# Altium schematic sheet ZB2.SchDoc(ZB2)
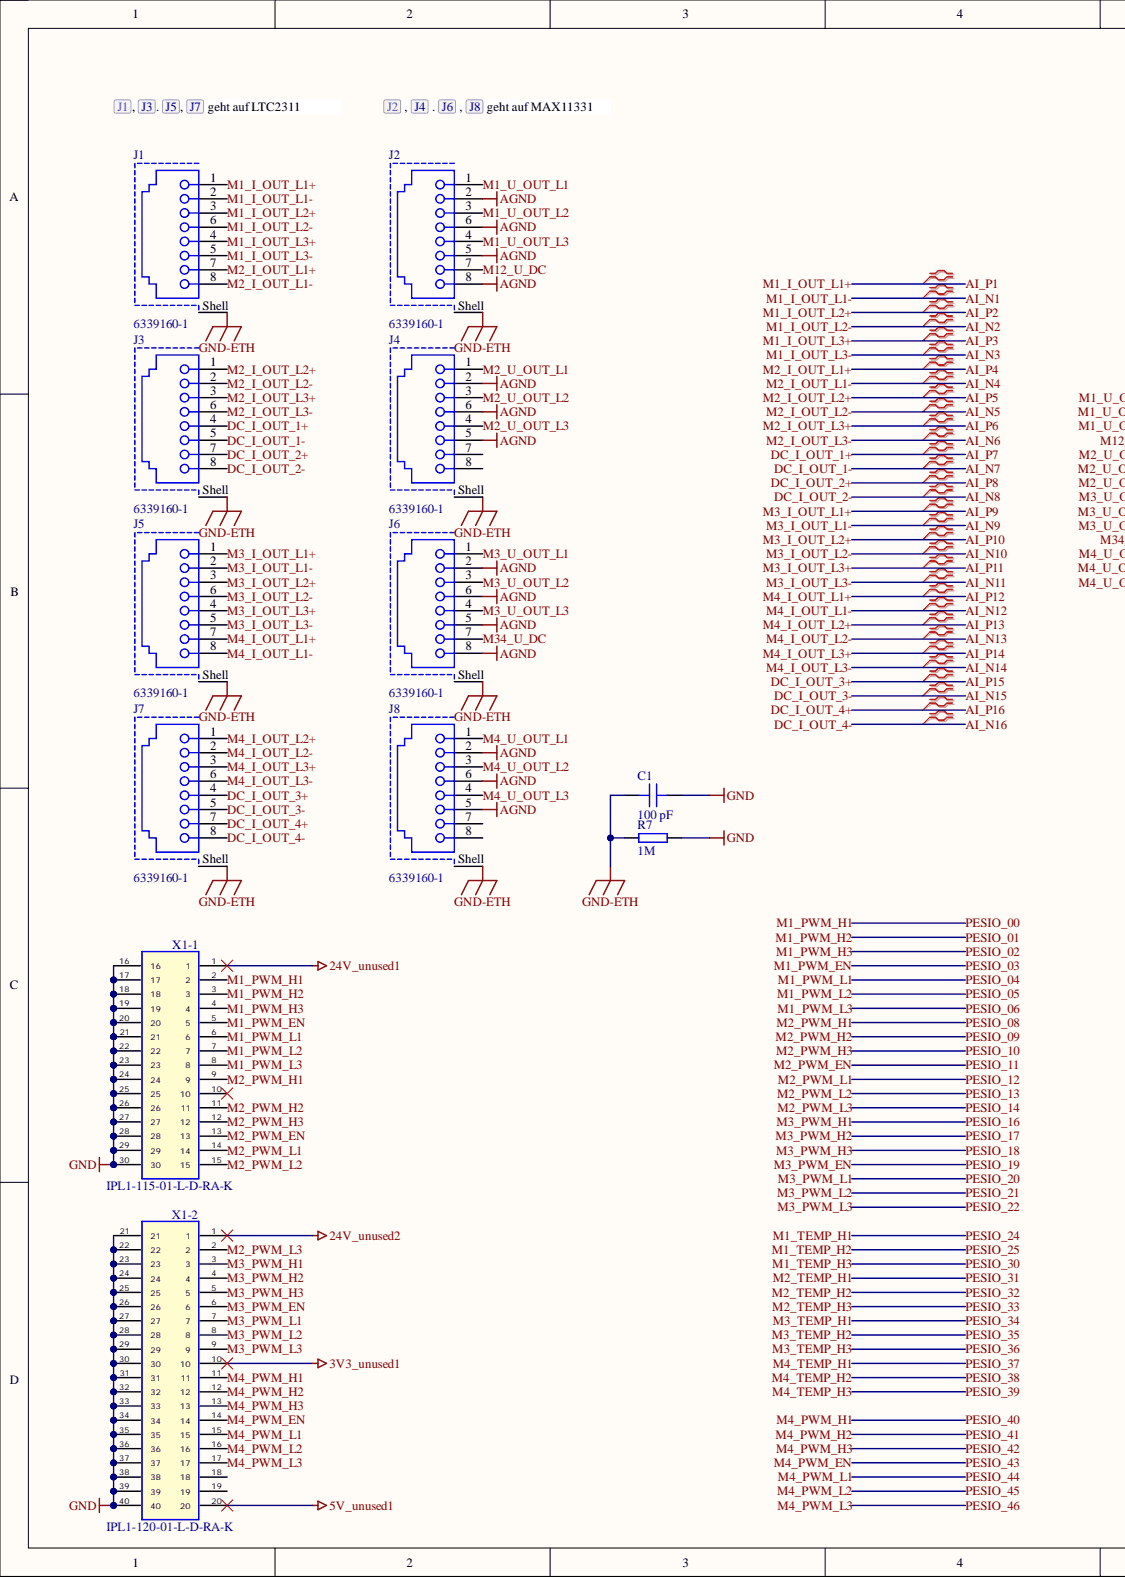
[diagram p0: ZB2.SchDoc, left]
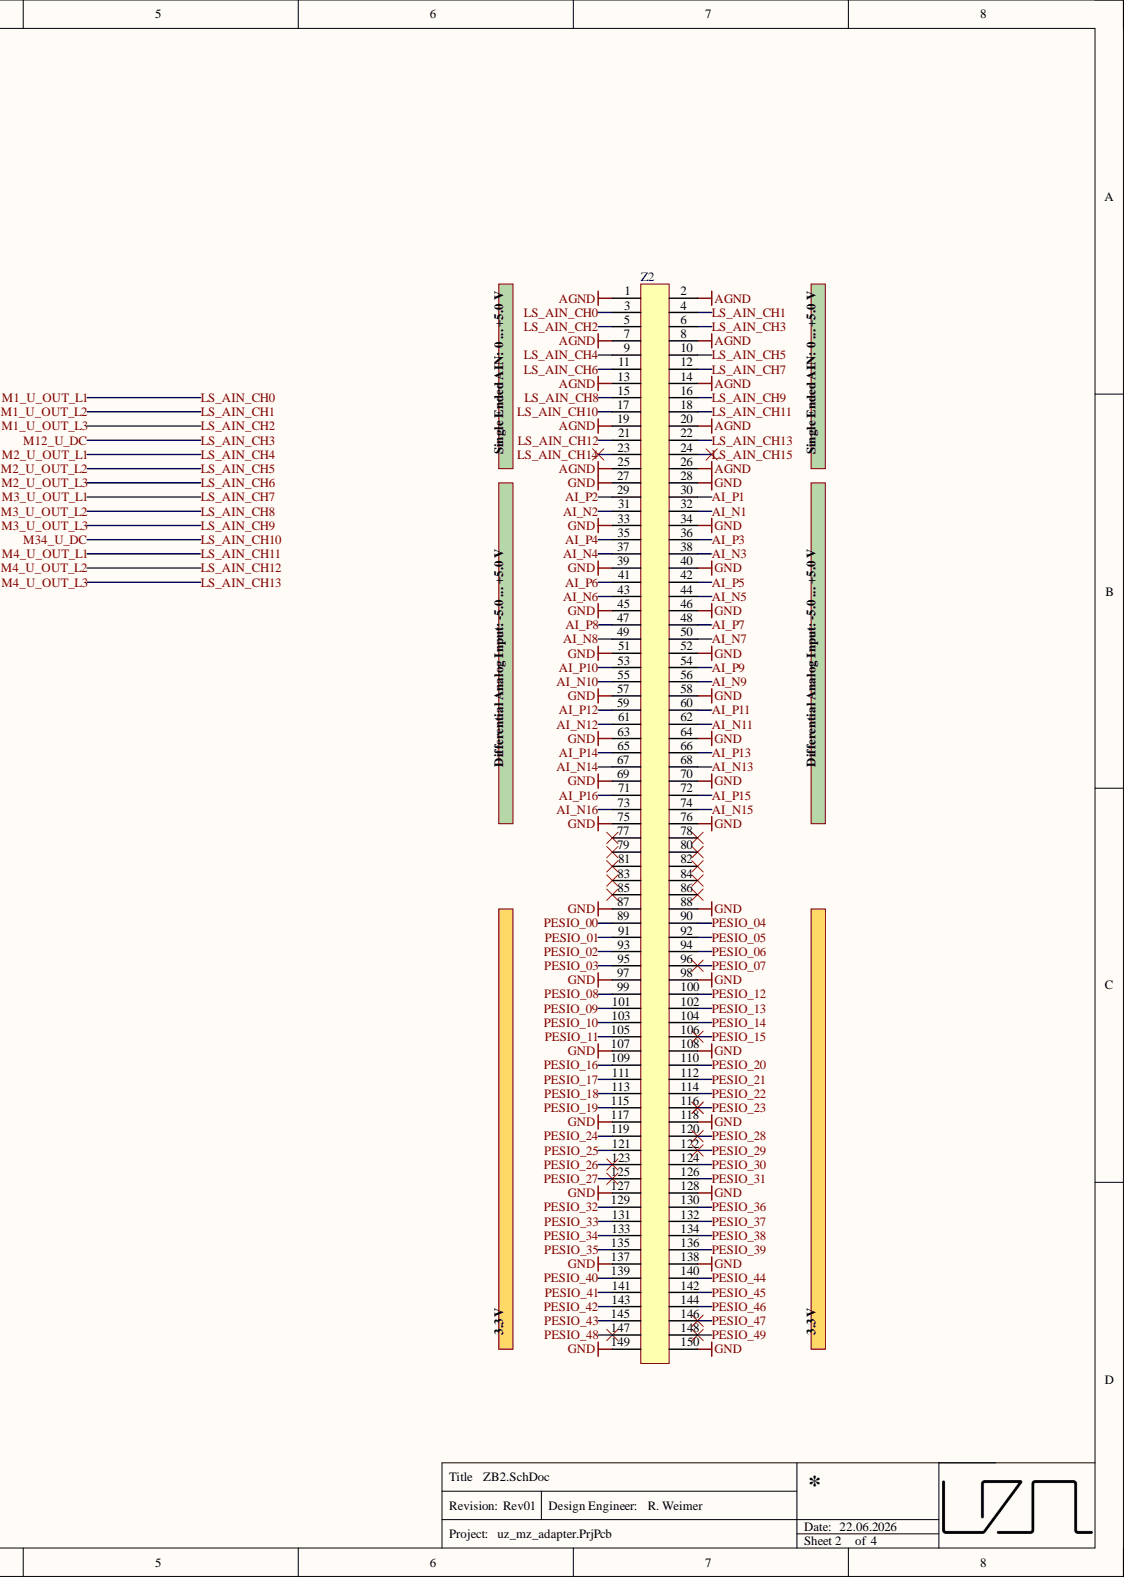
[diagram p1: ZB2.SchDoc, right]

## Parts
C1  100 pF  [C_1206_TE]
J1  6339160-1  [634008149821]
J2  6339160-1  [634008149821]
J3  6339160-1  [634008149821]
J4  6339160-1  [634008149821]
J5  6339160-1  [634008149821]
J6  6339160-1  [634008149821]
J7  6339160-1  [634008149821]
J8  6339160-1  [634008149821]
R7  1M  [RES 1206_3216]
X1-1  IPL1-115-01-L-D-RA-K  [IPL1-115-01-XX-D-RA]  (no BOM)
X1-2  IPL1-120-01-L-D-RA-K  [IPL1-120-01-XX-D-RA]  (no BOM)
Z2  ERM8-075-02.0-L-DV-TR  [ERM8-075-02.0-L-DV]  (value not drawn)

## Nets
3V3_unused1: X1-2.10
5V_unused1: X1-2.20
24V_unused1: X1-1.1
24V_unused2: X1-2.1
AGND: J2.2 J2.5 J2.6 J2.8 J4.2 J4.5 J4.6 J6.2 J6.5 J6.6 J6.8 J8.2 J8.5 J8.6 Z2.1 Z2.2 Z2.7 Z2.8 Z2.13 Z2.14 Z2.19 Z2.20 Z2.25 Z2.26
GND: C1.2 R7.2 X1-1.16 X1-1.17 X1-1.18 X1-1.19 X1-1.20 X1-1.21 X1-1.22 X1-1.23 X1-1.24 X1-1.25 X1-1.26 X1-1.27 X1-1.28 X1-1.29 X1-1.30 X1-2.21 X1-2.22 X1-2.23 X1-2.24 X1-2.25 X1-2.26 X1-2.27 X1-2.28 X1-2.29 X1-2.30 X1-2.31 X1-2.32 X1-2.33 X1-2.34 X1-2.35 X1-2.36 X1-2.37 X1-2.38 X1-2.39 X1-2.40 Z2.27 Z2.28 Z2.33 Z2.34 Z2.39 Z2.40 Z2.45 Z2.46 Z2.51 Z2.52 Z2.57 Z2.58 Z2.63 Z2.64 Z2.69 Z2.70 Z2.75 Z2.76 Z2.87 Z2.88 Z2.97 Z2.98 Z2.107 Z2.108 Z2.117 Z2.118 Z2.127 Z2.128 Z2.137 Z2.138 Z2.149 Z2.150
GND-ETH: C1.1 J1.Shell J2.Shell J3.Shell J4.Shell J5.Shell J6.Shell J7.Shell J8.Shell R7.1
AI_N1: J1.2 Z2.32
AI_N2: J1.6 Z2.31
AI_N3: J1.5 Z2.38
AI_N4: J1.8 Z2.37
AI_N5: J3.2 Z2.44
AI_N6: J3.6 Z2.43
AI_N7: J3.5 Z2.50
AI_N8: J3.8 Z2.49
AI_N9: J5.2 Z2.56
AI_N10: J5.6 Z2.55
AI_N11: J5.5 Z2.62
AI_N12: J5.8 Z2.61
AI_N13: J7.2 Z2.68
AI_N14: J7.6 Z2.67
AI_N15: J7.5 Z2.74
AI_N16: J7.8 Z2.73
AI_P1: J1.1 Z2.30
AI_P2: J1.3 Z2.29
AI_P3: J1.4 Z2.36
AI_P4: J1.7 Z2.35
AI_P5: J3.1 Z2.42
AI_P6: J3.3 Z2.41
AI_P7: J3.4 Z2.48
AI_P8: J3.7 Z2.47
AI_P9: J5.1 Z2.54
AI_P10: J5.3 Z2.53
AI_P11: J5.4 Z2.60
AI_P12: J5.7 Z2.59
AI_P13: J7.1 Z2.66
AI_P14: J7.3 Z2.65
AI_P15: J7.4 Z2.72
AI_P16: J7.7 Z2.71
LS_AIN_CH0: J2.1 Z2.3
LS_AIN_CH1: J2.3 Z2.4
LS_AIN_CH2: J2.4 Z2.5
LS_AIN_CH3: J2.7 Z2.6
LS_AIN_CH4: J4.1 Z2.9
LS_AIN_CH5: J4.3 Z2.10
LS_AIN_CH6: J4.4 Z2.11
LS_AIN_CH7: J6.1 Z2.12
LS_AIN_CH8: J6.3 Z2.15
LS_AIN_CH9: J6.4 Z2.16
LS_AIN_CH10: J6.7 Z2.17
LS_AIN_CH11: J8.1 Z2.18
LS_AIN_CH12: J8.3 Z2.21
LS_AIN_CH13: J8.4 Z2.22
LS_AIN_CH14: Z2.23
LS_AIN_CH15: Z2.24
M1_PWM_EN: X1-1.5 Z2.95
M1_PWM_H1: X1-1.2 Z2.89
M1_PWM_H2: X1-1.3 Z2.91
M1_PWM_H3: X1-1.4 Z2.93
M1_PWM_L1: X1-1.6 Z2.90
M1_PWM_L2: X1-1.7 Z2.92
M1_PWM_L3: X1-1.8 Z2.94
M1_TEMP_H1: Z2.119
M1_TEMP_H2: Z2.121
M1_TEMP_H3: Z2.124
M2_PWM_EN: X1-1.13 Z2.105
M2_PWM_H1: X1-1.9 Z2.99
M2_PWM_H2: X1-1.11 Z2.101
M2_PWM_H3: X1-1.12 Z2.103
M2_PWM_L1: X1-1.14 Z2.100
M2_PWM_L2: X1-1.15 Z2.102
M2_PWM_L3: X1-2.2 Z2.104
M2_TEMP_H1: Z2.126
M2_TEMP_H2: Z2.129
M2_TEMP_H3: Z2.131
M3_PWM_EN: X1-2.6 Z2.115
M3_PWM_H1: X1-2.3 Z2.109
M3_PWM_H2: X1-2.4 Z2.111
M3_PWM_H3: X1-2.5 Z2.113
M3_PWM_L1: X1-2.7 Z2.110
M3_PWM_L2: X1-2.8 Z2.112
M3_PWM_L3: X1-2.9 Z2.114
M3_TEMP_H1: Z2.133
M3_TEMP_H2: Z2.135
M3_TEMP_H3: Z2.130
M4_PWM_EN: X1-2.14 Z2.145
M4_PWM_H1: X1-2.11 Z2.139
M4_PWM_H2: X1-2.12 Z2.141
M4_PWM_H3: X1-2.13 Z2.143
M4_PWM_L1: X1-2.15 Z2.140
M4_PWM_L2: X1-2.16 Z2.142
M4_PWM_L3: X1-2.17 Z2.144
M4_TEMP_H1: Z2.132
M4_TEMP_H2: Z2.134
M4_TEMP_H3: Z2.136
PESIO_07: Z2.96
PESIO_15: Z2.106
PESIO_23: Z2.116
PESIO_26: Z2.123
PESIO_27: Z2.125
PESIO_28: Z2.120
PESIO_29: Z2.122
PESIO_47: Z2.146
PESIO_48: Z2.147
PESIO_49: Z2.148

Not connected: J4 7 8; J8 7 8; X1-1 10; X1-2 18 19; Z2 77 78 79 80 81 82 83 84 85 86

## Library data of the parts
C1 = CC1206JKNPODBN101: 2kV 100pF NP0 ±5% 1206 Multilayer Ceramic Capacitors MLCC - SMD/SMT ROHS; Manufacturer: YAGEO; Created from: AGeiger; Database Table Name: Capacitors - SMD; Voltage Rating: 2000 VDC; Component Kind: Standard; Component Type: Capacitor 1206; ComponentLink1Description: Datasheet; ComponentLink1URL: https://datasheet.lcsc.com/lcsc/2108131530_YAGEO-CC1206JKNPODBN101_C113876.pdf; Created On: 02.12.2022 11:59:53; Device Package: 1206; Last Updated: 22.04.2025 15:53:45; LCSC Part Number: C113876; Library Name: user.DbLib; Live Cycle Status: 1; Manufacturer Part Number: CC1206JKNPODBN101; Mounting Type: SMD; Operating Temperature: -55 to +125°C; PartID: 115; Series: NP0; Supplier 1: LCSC; Supplier Part Number 1: C113876; Tolerance: 5 %; Type: Capacitor; Value: 100 pF
J1-J8 = 6339160-1: RJ45 RJReceptacle cat5 Gold Phosphor bronze Without LED -40℃~+85℃ SMD Ethernet Connectors/Modular Connectors (RJ45 RJ11) ROHS; Manufacturer: TE Connectivity; Contact Resistance: 20mOhm; Created from: NDiringer; Database Table Name: Interface - Ethernet; Insulation Resistance: 500MOhm; LED: No; Match Code: WR-MJ; Number of Ports: 1; Rated Current: 1.5A; Shielding: Yes; Withstanding Voltage: 1000VAC; Working Voltage: 120VAC; Category: Input/Output Connectors; Component Kind: Standard; Component Type: RJ45 Connector; ComponentLink1Description: Datasheet; ComponentLink1URL: https://jlcpcb.com/partdetail/TeConnectivity-63391601/C125076; ComponentLink2Description: Datasheet; ComponentLink2URL: https://www.we-online.com/redexpert/spec/634008149821?ad; Created On: 20.04.2023 12:29:11; Device Package: RJ45; Last Updated: 21.02.2024 15:07:56; LCSC Part Number: C125076; Library Name: user.DbLib; Live Cycle Status: 1; Manufacturer Part Number: 6339160-1; Mount: Surface Mount; Mounting Type: SMD; Number of Pins: 8P8C; Operating Temperature Max: 85°C; Operating Temperature Min: -40°C; Orientation: Horizontal; Packaging: Tray; PartID: 8; Series: RJHSE; Stock number: not stored; Supplier 1: LCSC; Supplier Part Number 1: C125076; Type: RJ45 Connector
R7 = 1206W4F1004T5E: 250mW Thick Film Resistors 200V ±100ppm/℃ ±1% -55℃~+155℃ 1MΩ 1206 Chip Resistor - Surface Mount ROHS; Manufacturer: UNI-ROYAL; Created from: AGeiger; Database Table Name: Resistors - SMD; Component Kind: Standard; Component Type: SMD resistor; ComponentLink1Description: Datasheet; ComponentLink1URL: https://datasheet.lcsc.com/lcsc/2206010145_UNI-ROYAL-Uniroyal-Elec-1206W4F1004T5E_C17927.pdf; Created On: 02.12.2022 11:50:50; Device Package: 1206; Last Updated: 02.12.2022 11:50:50; LCSC Part Number: C17927; Library Name: user.DbLib; Live Cycle Status: 1; Manufacturer Part Number: 1206W4F1004T5E; Mounting Type: SMD; PartID: 248; Supplier 1: LCSC; Supplier Part Number 1: C17927; Tolerance: 1%; Type: Resistor; Value: 1M
X1-1 = IPL1-115-01-L-D-RA-K: Terminal Assembly, Double Row, Right Angle, Thru-Hole, 2.54 mm x 2.54 mm Pitch, 30 Pins; Manufacturer: SAMTEC; Created from: TWendt; Database Table Name: Connectors - Wire to Board; Number of positions: 30; Component Type: Samtec Connector; ComponentLink1Description: Homepage; ComponentLink1URL: https://www.samtec.com/products/ipl1; Created On: 12.02.2021 15:31:18; Device Package: Connector; Last Updated: 15.02.2021 10:07:42; Library Name: user.DbLib; Live Cycle Status: 1; Manufacturer Part Number: IPL1-115-01-L-D-RA-K; Number of rows: 2; PartID: 34; Stock number: not stored; Supplier 1: SAMTEC; Supplier Part Number 1: IPL1-115-01-L-D-RA-K; Type: Connector
X1-2 = IPL1-120-01-L-D-RA-K: Terminal Assembly, Double Row, Right Angle, Thru-Hole, 2.54 mm x 2.54 mm Pitch, 40 Pins; Manufacturer: SAMTEC; Created from: TWendt; Database Table Name: Connectors - Wire to Board; Number of positions: 40; Component Type: Samtec Connector; ComponentLink1Description: Homepage; ComponentLink1URL: https://www.samtec.com/products/ipl1; Created On: 12.02.2021 15:26:11; Device Package: Connector; Last Updated: 15.02.2021 10:07:42; Library Name: user.DbLib; Live Cycle Status: 1; Manufacturer Part Number: IPL1-120-01-L-D-RA-K; Mounting Type: THT; Number of rows: 2; PartID: 33; Stock number: not stored; Supplier 1: SAMTEC; Supplier Part Number 1: IPL1-120-01-L-D-RA-K; Type: Connector
Z2 = ERM8-075-02.0-L-DV-TR: 0.80 mm Edge Rate Rugged High Speed; Manufacturer: SAMTEC; Created from: AGeiger; Database Table Name: Connector; Component Kind: Standard; ComponentLink1Description: Datasheet; ComponentLink1URL: https://suddendocs.samtec.com/catalog_english/erm8.pdf; Created On: 15.08.2025 09:35:44; Last Updated: 23.09.2025 10:14:21; LCSC Part Number: C6845629; Library Name: user.DbLib; Manufacturer Part Number: ERM8-075-02.0-L-DV-TR; Mounting Type: SMD; Operating Temperature: -55 °C to +125 °C; PartID: 62; Series: ERM8; Supplier 1: LCSC; Supplier Part Number 1: C6845629; Value: ERM8-075-02.0-L-DV-TR
